# Altium schematic sheet POWER.SchDoc(POWER)
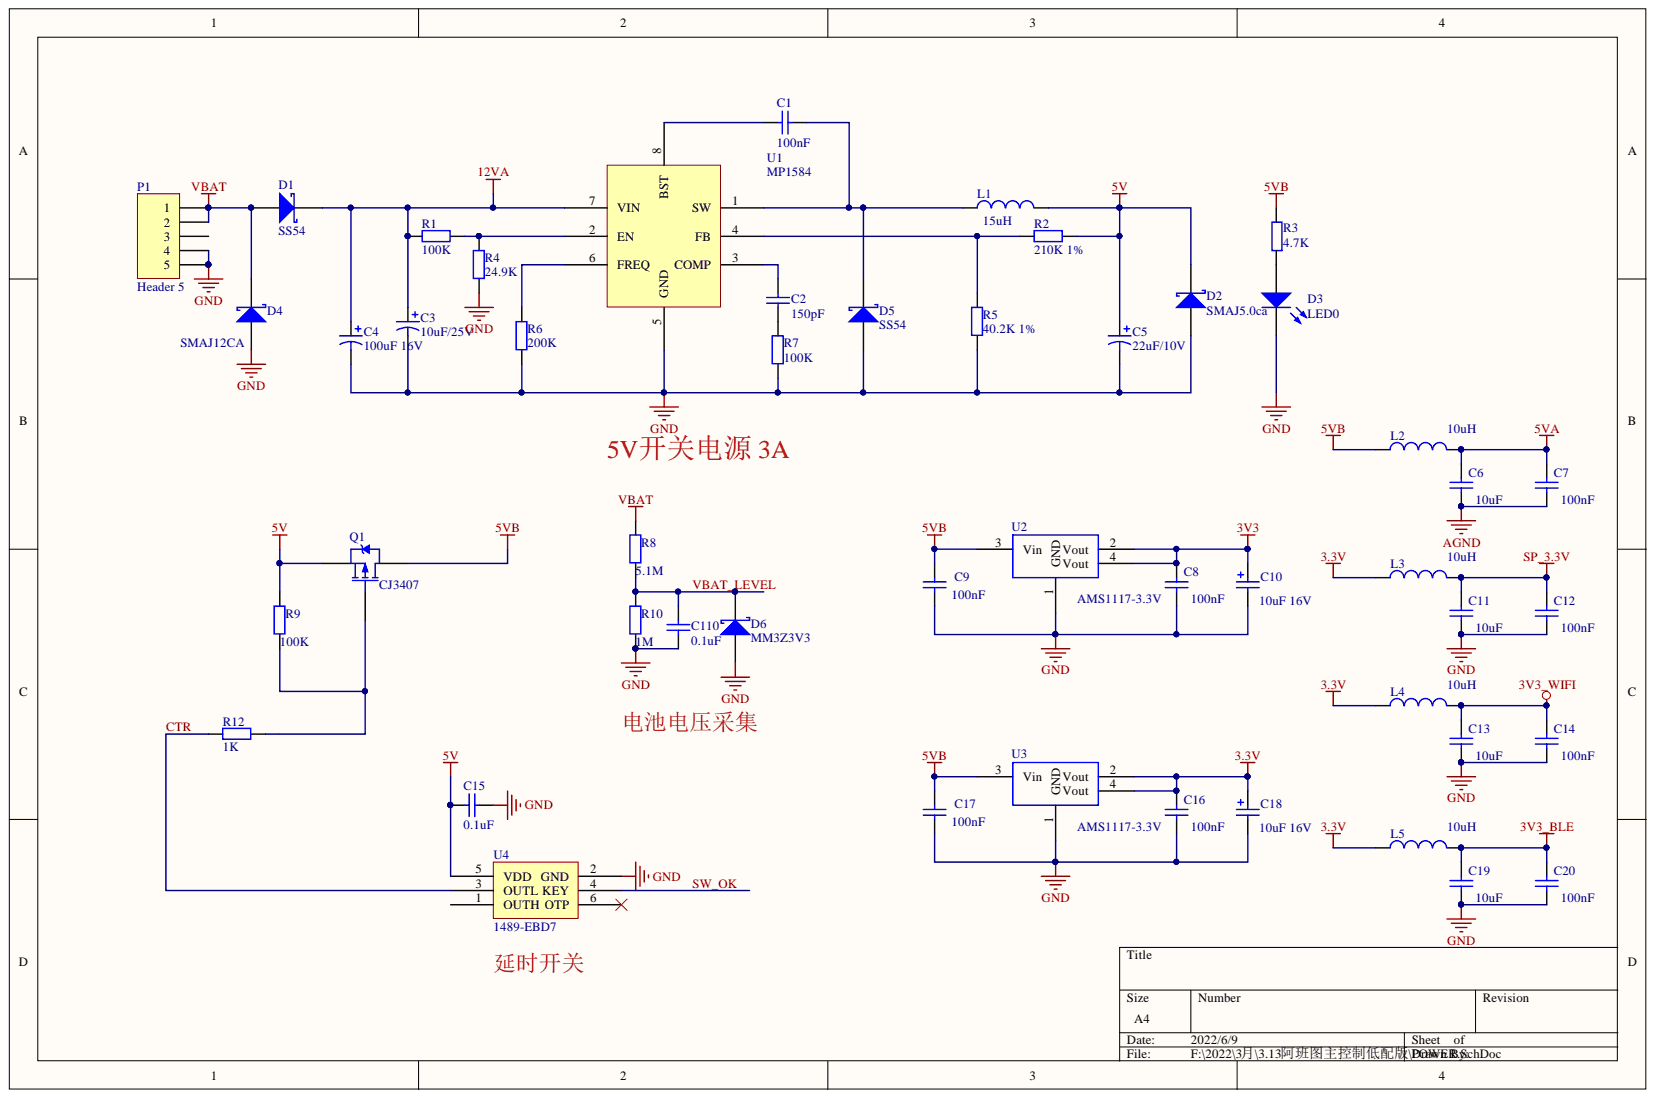
[diagram p0: POWER.SchDoc]

## Parts
C1  100nF  [C0603]
C2  150pF  [C0603]
C3  10uF/25V  [1206C+]
C4  100uF 16V  [EIA7343]
C5  22uF/10V  [1206C+]
C6  10uF  [C0603]
C7  100nF  [C0603]
C8  100nF  [C0603]
C9  100nF  [C0603]
C10  10uF 16V  [1206C+]
C11  10uF  [C0603]
C12  100nF  [C0603]
C13  10uF  [C0603]
C14  100nF  [C0603]
C15  0.1uF  [C0603]
C16  100nF  [C0603]
C17  100nF  [C0603]
C18  10uF 16V  [1206C+]
C19  10uF  [C0603]
C20  100nF  [C0603]
C110  0.1uF  [C0603]
D1  SS54  [SMA/DO-214AC]
D2  SMAJ5.0ca  [SMA/DO-214AC]
D3  LED0  [LED_0805]
D4  SMAJ12CA  [SMA/DO-214AC]
D5  SS54  [SMA/DO-214AC]
D6  MM3Z3V3  [SOD323]
L1  15uH  [L_0420]
L2  10uH  [R0603]
L3  10uH  [R0603]
L4  10uH  [R0603]
L5  10uH  [R0603]
P1  Header 5  [BAT_D_5]
Q1  CJ3407  [SOT23]
R1  100K  [R0603]
R2  210K 1%  [R0603]
R3  4.7K  [R0603]
R4  24.9K  [R0603]
R5  40.2K 1%  [R0603]
R6  200K  [R0603]
R7  100K  [R0603]
R8  5.1M  [R0603]
R9  100K  [R0603]
R10  1M  [R0603]
R12  1K  [R0603]
U1  MP1584  [SOIC_8e]
U2  AMS1117-3.3V  [sot-223]
U3  AMS1117-3.3V  [sot-223]
U4  1489-EBD7  [SOT23_6]

## Nets
3.3V: C16.2 C18.1 L3.1 L4.1 L5.1 U3.2(Vout) U3.4(Vout)
3V3: C8.2 C10.1 U2.2(Vout) U2.4(Vout)
3V3_BLE: C19.2 C20.2 L5.2
3V3_WIFI: C13.2 C14.2 L4.2
5V: C5.1 C15.1 D2.2 L1.2 Q1.2 R2.1 R9.2 U4.5(VDD)
5VA: C6.2 C7.2 L2.2
5VB: C9.2 C17.2 L2.1 Q1.3 R3.2 U2.3(Vin) U3.3(Vin)
12VA: C3.1 C4.1 D1.2 R1.1 U1.7(VIN)
AGND: C6.1 C7.1
GND: C3.2 C4.2 C5.2 C8.1 C9.1 C10.2 C11.1 C12.1 C13.1 C14.1 C15.2 C16.1 C17.1 C18.2 C19.1 C20.1 C110.1 D2.1 D3.2 D4.1 D5.1 D6.1 P1.4 P1.5 R4.1 R5.1 R6.1 R7.1 R10.1 U1.5(GND) U2.1(GND) U3.1(GND) U4.2(GND)
SP_3.3V: C11.2 C12.2 L3.2
VBAT: D1.1 D4.2 P1.1 P1.2 R8.2
CTR: R12.2 U4.3(OUTL)
SW_OK: U4.4(KEY)
VBAT_LEVEL: C110.2 D6.2 R8.1 R10.2
NetC1_1: C1.1 D5.2 L1.1 U1.1(SW)
NetC1_2: C1.2 U1.8(BST)
NetC2_1: C2.1 U1.3(COMP)
NetC2_2: C2.2 R7.2
NetD3_1: D3.1 R3.1
NetQ1_1: Q1.1 R9.1 R12.1
NetR1_2: R1.2 R4.2 U1.2(EN)
NetR2_2: R2.2 R5.2 U1.4(FB)
NetR6_2: R6.2 U1.6(FREQ)

Not connected: P1 3; U4 1(OUTH) 6(OTP)

## Library data of the parts
C1 C2 = Cap: Capacitor; Value: 100pF; Component Kind: Standard; LatestRevisionDate: 17-Jul-2002; LatestRevisionNote: Re-released for DXP Platform.; Library Name: Miscellaneous Devices.IntLib; PackageDescription: Radial Cap, Thru-Hole; 2 Leads; 0.3 in Pin Spacing; PackageReference: RAD-0.3; Published: 8-Jun-2000; Signal Integrity: Cap; Simulation: CAP; Publisher: Altium Limited
C3-C5 = Cap Pol1: Polarized Capacitor (Radial); Value: 100pF; Component Kind: Standard; LatestRevisionDate: 17-Jul-2002; LatestRevisionNote: Re-released for DXP Platform.; Library Name: Miscellaneous Devices.IntLib; PackageDescription: Polarized Capacitor (Radial); 2 Leads; PackageReference: RB7.6-15; Published: 8-Jun-2000; Publisher: Altium Limited
  C3 C5: Signal Integrity: Cap Pol; Simulation: CAP
C6-C9 C11-C14 C16 C17 C19 C20 = 0603 100nF (104) 10% 50V: 贴片电容; Component Kind: Standard; ComponentLink1Description: 供应商链接; ComponentLink1URL: http://www.szlcsc.com/product/details_15331.html; Library Name: Miscellaneous Devices LC.IntLib; Package: 0603; Supplier: LC; SuppliersPartNumber: C14663
C10 C18 = 3528 100uF (107) 10% 6.3V: 钽电容; Component Kind: Standard; ComponentLink1Description: 供应商链接; ComponentLink1URL: http://www.szlcsc.com/product/details_16815.html; Library Name: Miscellaneous Devices LC.IntLib; Package: 3528; Supplier: LC; SuppliersPartNumber: C16133
C15 C110 = Cap: Capacitor; Component Kind: Standard; LatestRevisionDate: 17-Jul-2002; LatestRevisionNote: Re-released for DXP Platform.; Library Name: Miscellaneous Devices.IntLib; PackageReference: RAD-0.3; Published: 8-Jun-2000; Value: 0.1uF; Publisher: Altium Limited
D1 D2 D4-D6 = D Schottky: Schottky Diode; Code_JEDEC: DO-214-AA; Component Kind: Standard; LatestRevisionDate: 17-Jul-2002; LatestRevisionNote: Re-released for DXP Platform.; Library Name: Miscellaneous Devices.IntLib; PackageDescription: DO-214-AA/SMB; 2 C-Bend Leads; Body 5.3 x 3.6 mm, inc. leads (LxW); PackageReference: SMB; Published: 8-Jun-2000; Signal Integrity: D Schottky; Simulation: SKYDIODE; Publisher: Altium Limited
D3 = LED0: Typical INFRARED GaAs LED; Component Kind: Standard; LatestRevisionDate: 08-Jul-2005; LatestRevisionNote: Mech Layer 1 removed.; Library Name: Miscellaneous Devices.IntLib; PackageDescription: LED; 2 Leads; PackageReference: LED-0; Published: 8-Jun-2000; Simulation: LED0; Publisher: Altium Limited
L1-L5 = Inductor: Code_IEC: 1005; Value: 10mH; Code_JEITA: 0402; Component Kind: Standard; Description: Inductor; LatestRevisionDate: 29-May-2009; LatestRevisionNote: IPC-7351 Footprint Added.; Library Name: Miscellaneous Devices.IntLib; PackageDescription: Chip Inductor; PackageReference: 0402-A; Published: 8-Jun-2000; Signal Integrity: Inductor; Simulation: INDUCTOR; Publisher: Altium Limited
P1 = Header 5: Header, 5-Pin; Component Kind: Standard; LatestRevisionDate: 17-Jul-2002; LatestRevisionNote: Re-released for DXP Platform.; Library Name: Miscellaneous Connectors.IntLib; Publisher: Altium Limited
Q1 = PMOS-2: P-Channel Power MOSFET; Note: Pin 4 not drawn and electrically connected to Pin 2.; Component Kind: Standard; ComponentLink1Description: Manufacturer Link; ComponentLink1URL: http://www.irf.com/; DatasheetVersion: PD-9.390D; LatestRevisionDate: 17-Jul-2002; LatestRevisionNote: Re-released for DXP Platform.; Library Name: Miscellaneous Devices.IntLib; PackageDescription: TO, Thru-Hole, Vertical, Heatsink Mounted; 3 In-Line Leads; Pitch 2.54 mm; PackageReference: TO-220AB; PackageVersion: Feb-1997; Published: 8-Jun-2000; Simulation: IRF9510; Publisher: Altium Limited
R1 R2 R4-R10 = Res2: Resistor; Value: 1K; Component Kind: Standard; LatestRevisionDate: 17-Jul-2002; LatestRevisionNote: Re-released for DXP Platform.; Library Name: Miscellaneous Devices.IntLib; PackageDescription: Axial Device, Thru-Hole; 2 Leads; 0.4 in Pin Spacing; PackageReference: AXIAL-0.4; Published: 8-Jun-2000; Signal Integrity: Res; Simulation: RESISTOR; Publisher: Altium Limited
R3 = R: Component Kind: Standard; Library Name: Explorer STM32F407封装库.IntLib
R12 = Res2: Resistor; Component Kind: Standard; LatestRevisionDate: 17-Jul-2002; LatestRevisionNote: Re-released for DXP Platform.; Library Name: Miscellaneous Devices.IntLib; PackageDescription: Axial Device, Thru-Hole; 2 Leads; 0.4 in Pin Spacing; PackageReference: AXIAL-0.4; Published: 8-Jun-2000; Signal Integrity: Res; Simulation: RESISTOR; Value: 1K; Publisher: Altium Limited
U2 U3 = 1117M3: Component Kind: Standard; Library Name: 芯片类.SchLib
U4 = EC20032402-V01-2992: Component Kind: Standard; Library Name: 芯片类.SchLib
U1 = MP1584: Component Kind: Standard; Library Name: 芯片类ku.SchLib
